# Altium schematic sheet IO.SchDoc
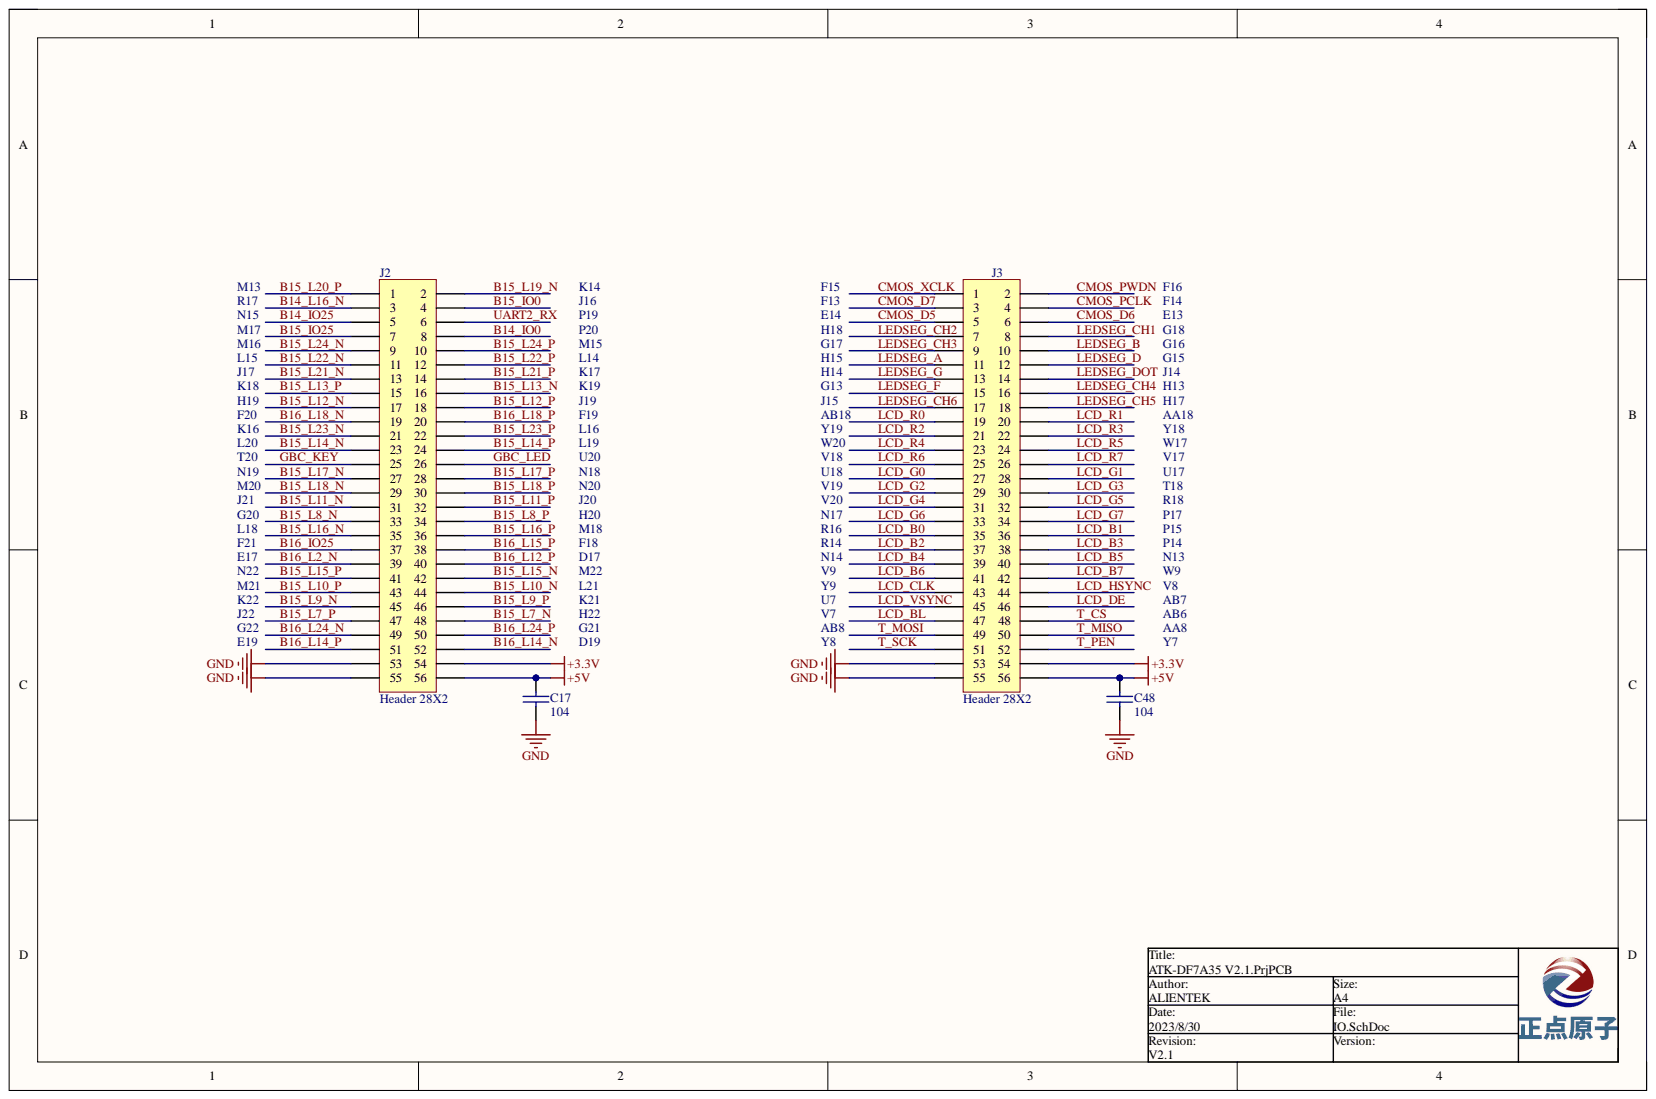
[diagram p0: IO.SchDoc]

## Parts
C17  104  [0603P]
C48  104  [0603P]
J2  Header 28X2  [HDR2x28]
J3  Header 28X2  [HDR2x28]

## Nets
+3.3V: J2.54 J3.54
+5V: C17.1 C48.1 J2.56 J3.56
GND: C17.2 C48.2 J2.53 J2.55 J3.53 J3.55
B14_IO0: J2.8
B14_IO25: J2.5
B14_L16_N: J2.3
B15_IO0: J2.4
B15_IO25: J2.7
B15_L7_N: J2.48
B15_L7_P: J2.47
B15_L8_N: J2.33
B15_L8_P: J2.34
B15_L9_N: J2.45
B15_L9_P: J2.46
B15_L10_N: J2.44
B15_L10_P: J2.43
B15_L11_N: J2.31
B15_L11_P: J2.32
B15_L12_N: J2.17
B15_L12_P: J2.18
B15_L13_N: J2.16
B15_L13_P: J2.15
B15_L14_N: J2.23
B15_L14_P: J2.24
B15_L15_N: J2.42
B15_L15_P: J2.41
B15_L16_N: J2.35
B15_L16_P: J2.36
B15_L17_N: J2.27
B15_L17_P: J2.28
B15_L18_N: J2.29
B15_L18_P: J2.30
B15_L19_N: J2.2
B15_L20_P: J2.1
B15_L21_N: J2.13
B15_L21_P: J2.14
B15_L22_N: J2.11
B15_L22_P: J2.12
B15_L23_N: J2.21
B15_L23_P: J2.22
B15_L24_N: J2.9
B15_L24_P: J2.10
B16_IO25: J2.37
B16_L2_N: J2.39
B16_L12_P: J2.40
B16_L14_N: J2.52
B16_L14_P: J2.51
B16_L15_P: J2.38
B16_L18_N: J2.19
B16_L18_P: J2.20
B16_L24_N: J2.49
B16_L24_P: J2.50
CMOS_D5: J3.5
CMOS_D6: J3.6
CMOS_D7: J3.3
CMOS_PCLK: J3.4
CMOS_PWDN: J3.2
CMOS_XCLK: J3.1
GBC_KEY: J2.25
GBC_LED: J2.26
LCD_B0: J3.35
LCD_B1: J3.36
LCD_B2: J3.37
LCD_B3: J3.38
LCD_B4: J3.39
LCD_B5: J3.40
LCD_B6: J3.41
LCD_B7: J3.42
LCD_BL: J3.47
LCD_CLK: J3.43
LCD_DE: J3.46
LCD_G0: J3.27
LCD_G1: J3.28
LCD_G2: J3.29
LCD_G3: J3.30
LCD_G4: J3.31
LCD_G5: J3.32
LCD_G6: J3.33
LCD_G7: J3.34
LCD_HSYNC: J3.44
LCD_R0: J3.19
LCD_R1: J3.20
LCD_R2: J3.21
LCD_R3: J3.22
LCD_R4: J3.23
LCD_R5: J3.24
LCD_R6: J3.25
LCD_R7: J3.26
LCD_VSYNC: J3.45
LEDSEG_A: J3.11
LEDSEG_B: J3.10
LEDSEG_CH1: J3.8
LEDSEG_CH2: J3.7
LEDSEG_CH3: J3.9
LEDSEG_CH4: J3.16
LEDSEG_CH5: J3.18
LEDSEG_CH6: J3.17
LEDSEG_D: J3.12
LEDSEG_DOT: J3.14
LEDSEG_F: J3.15
LEDSEG_G: J3.13
T_CS: J3.48
T_MISO: J3.50
T_MOSI: J3.49
T_PEN: J3.52
T_SCK: J3.51
UART2_RX: J2.6

## Library data of the parts
C17 C48 = CAP_104_0.1uf: Component Kind: Standard; Library Name: ZYNQ.SCHLIB
J2 J3 = Header 28X2: Header, 20-Pin, Dual row; Component Kind: Standard; LatestRevisionDate: 17-Jul-2002; LatestRevisionNote: Re-released for DXP Platform.; Library Name: Sheet_1.SCHLIB; Publisher: Altium Limited
